# Altium schematic sheet 0_Overview.SchDoc
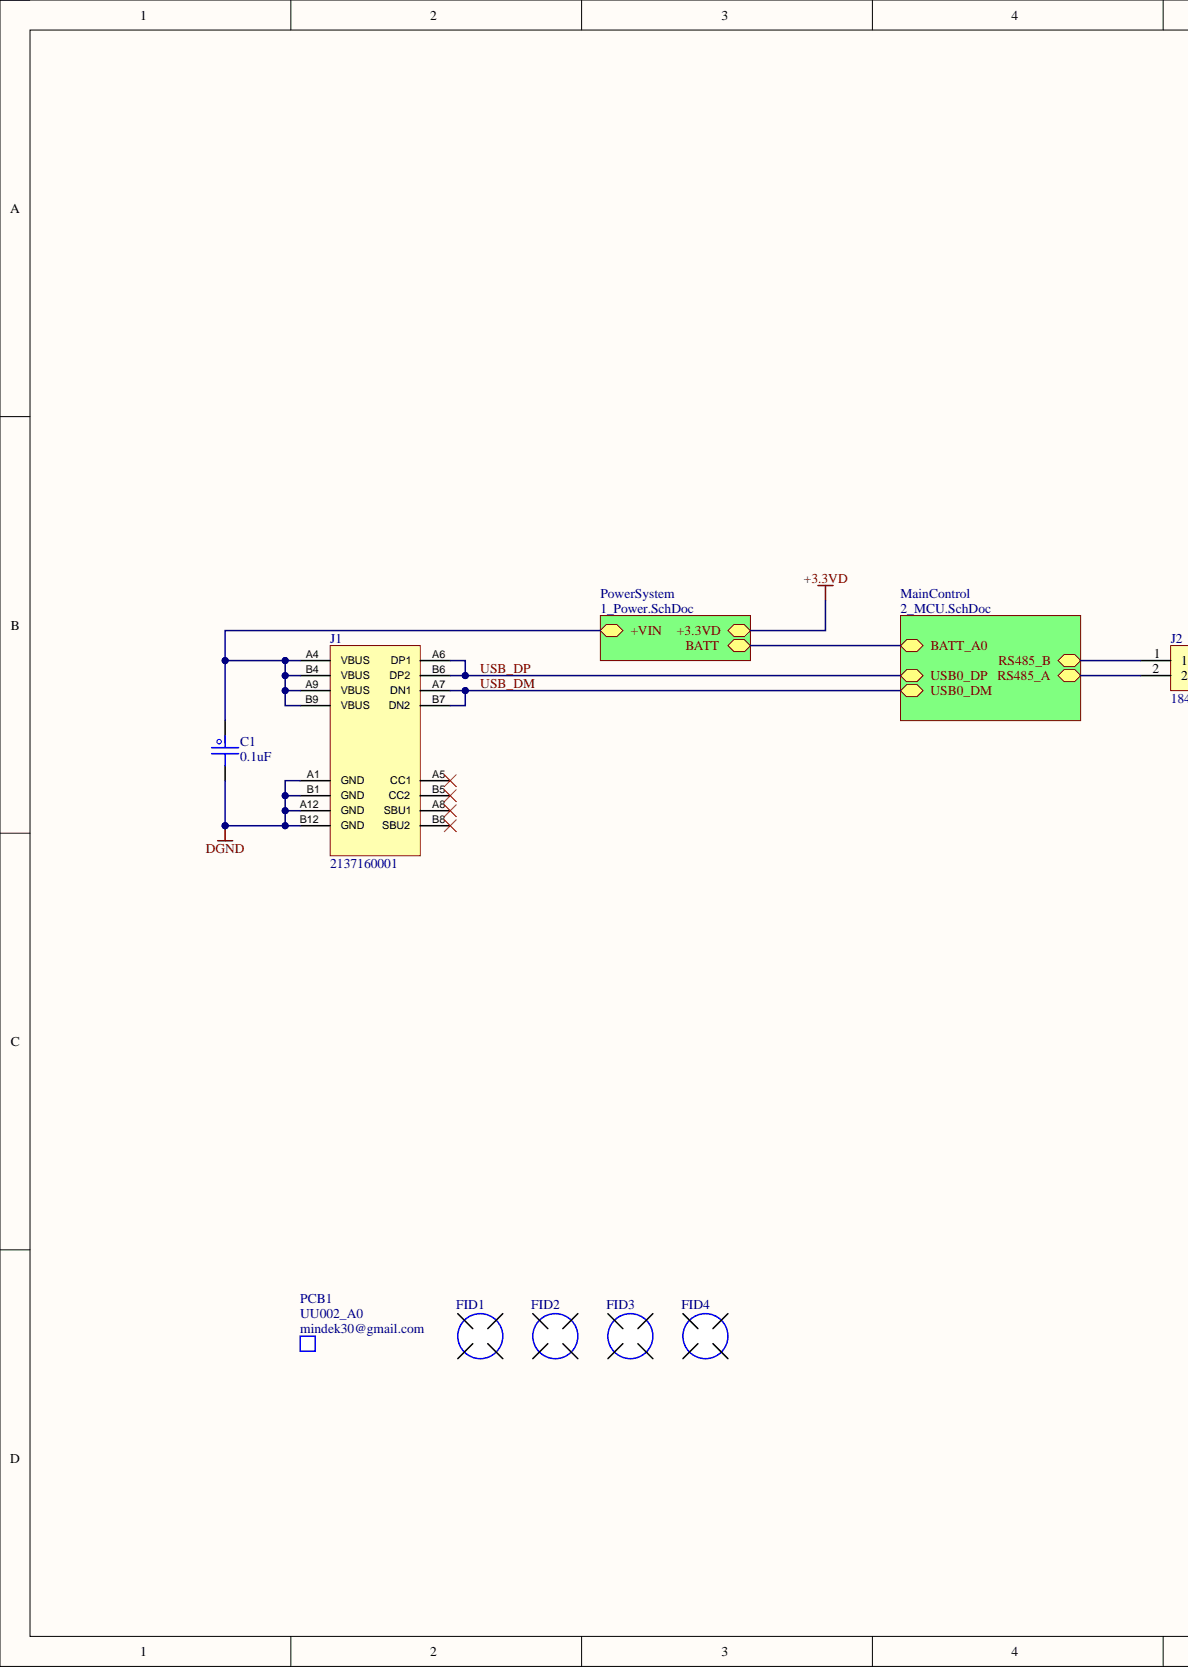
[diagram p0: 0_Overview.SchDoc, left]
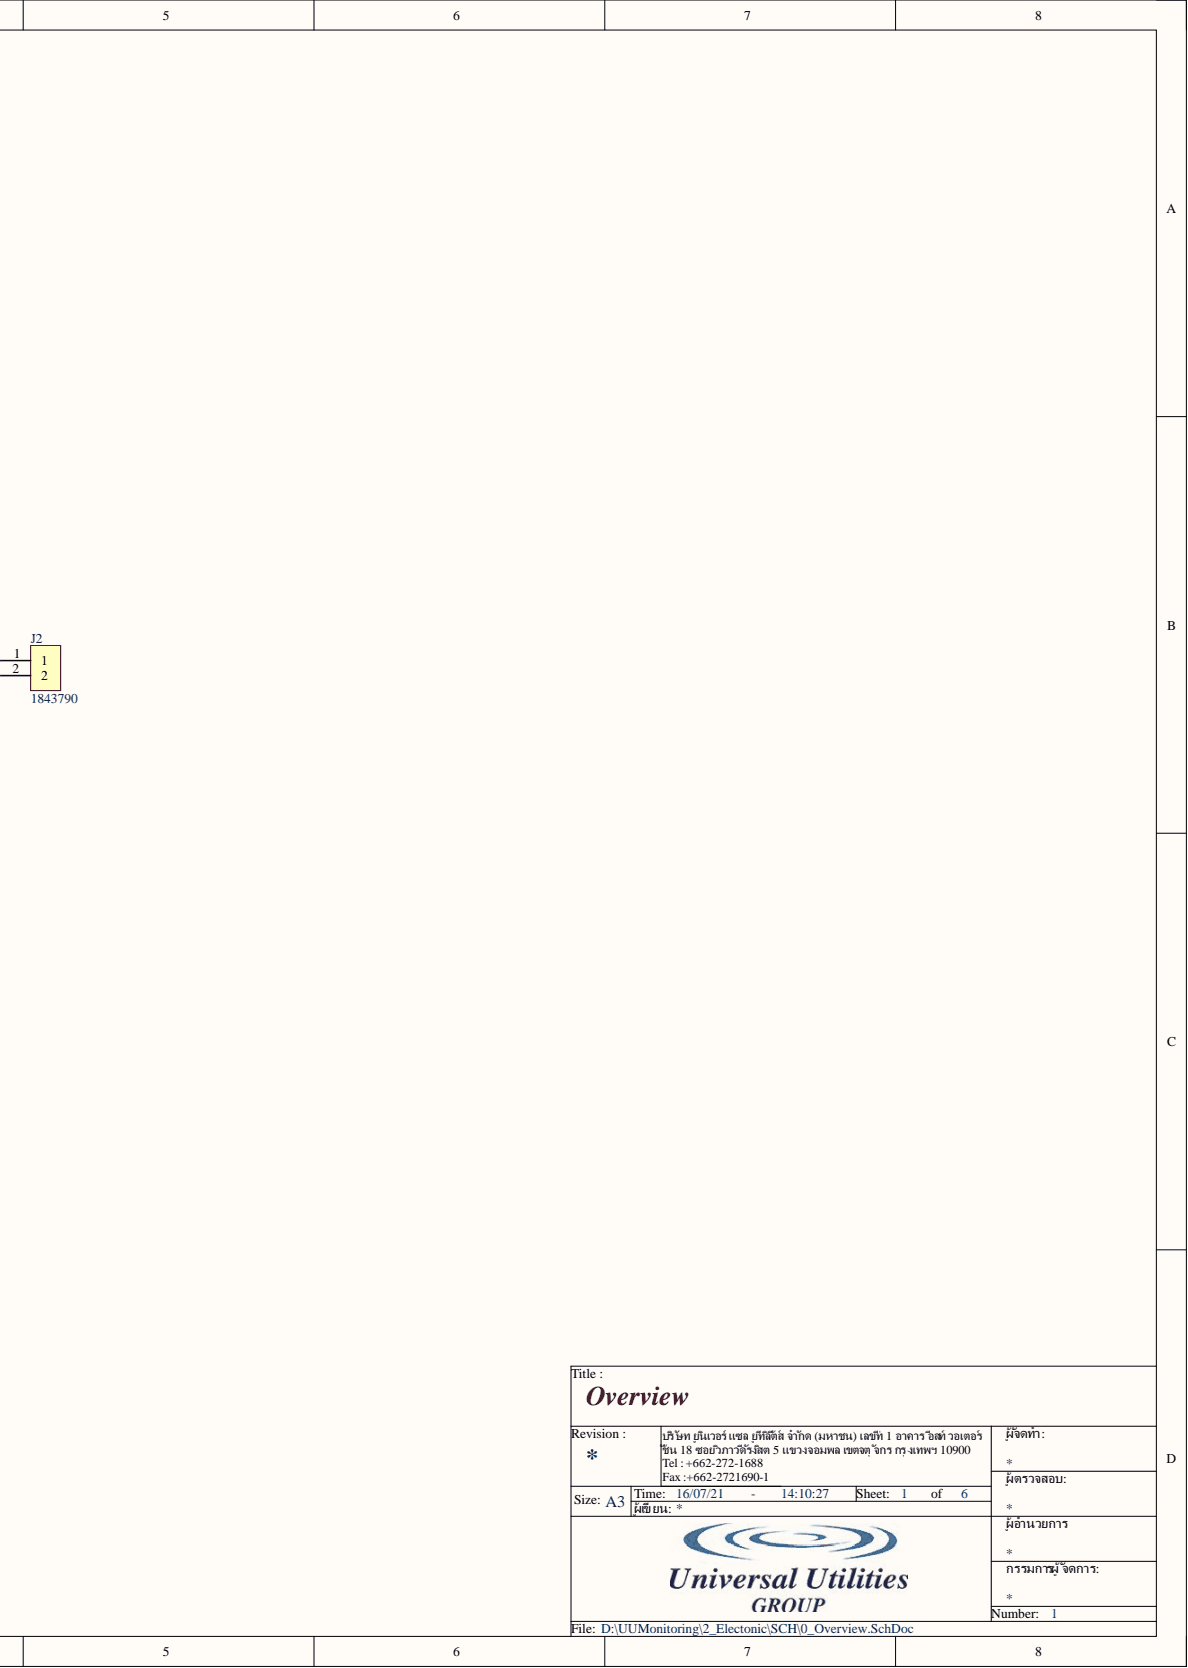
[diagram p1: 0_Overview.SchDoc, right]

## Parts
C1  0.1uF  [C_0603]
FID1  FIDUCIAL  [FID]  (value not drawn)  (no BOM)
FID2  FIDUCIAL  [FID]  (value not drawn)  (no BOM)
FID3  FIDUCIAL  [FID]  (value not drawn)  (no BOM)
FID4  FIDUCIAL  [FID]  (value not drawn)  (no BOM)
J1  2137160001  [2137160001_MOL_2137160001(Primary)]
J2  1843790  [CON_2P_Terminal]
PCB1  UU002_A0  Manufacturer: mindek30@gmail.com  (mechanical)

## Nets
DGND: C1.2 J1.A1(GND) J1.A12(GND) J1.B1(GND) J1.B12(GND)
USB_DM: J1.A7(DN1) J1.B7(DN2)
USB_DP: J1.A6(DP1) J1.B6(DP2)
NetC1_1: C1.1 J1.A4(VBUS) J1.A9(VBUS) J1.B4(VBUS) J1.B9(VBUS)
NetJ1_A5: J1.A5(CC1)
NetJ1_A8: J1.A8(SBU1)
NetJ1_B5: J1.B5(CC2)
NetJ1_B8: J1.B8(SBU2)
NetJ2_1: J2.1
NetJ2_2: J2.2
+3.3VD:
BATT:

## Library data of the parts
C1 = C_Capacitor: CAP CER 0.1UF 50V X7R 0603; Manufacturer: KEMET; PartNumber: C0603C104K5RACTU; Component Kind: Standard; PackageReference: 0603; PartID: 399-5089-1-ND; Value: 0.1uF; Value2: 50V; Library Name: UUSymbol.SchLib
FID1-FID4 = FID_FIDUCIAL: Manufacturer: mindek30@gmail.com; PartNumber: UU002_A0; Value: Non SE; Description: Fiducial; Library Name: UUSymbol.SchLib; PackageReference: Non SE; PartID: A0; Value2: Non SE; Value3: Non SE
J1 = CON_USBC: CONN RCPT USB2.0 TYPE C 24POS RA; Manufacturer: Molex; Component Kind: Standard; PartID: 900-2137160001CT-ND; PartNumber: 2137160001; Value: 2137160001; Library Name: UUSymbol.SchLib
J2 = CON_2P_Terminal: TERM BLOCK HDR 2POS 90DEG 3.5MM; Manufacturer: Phoenix Contact; Component Kind: Standard; PartID: 277-5882-ND; PartNumber: 1843790; Value: 1843790; Library Name: UUSymbol.SchLib
PCB1 = PCB_Bare: BARE PCB; Library Name: UUSymbol.SchLib; PackageReference: Non SE; PartID: A0; PartNumber: UU002_A0; Value: UU002_A0; Value3: UU002_A0
